# Altium schematic sheet [03] POWER.SchDoc("[03] POWER")
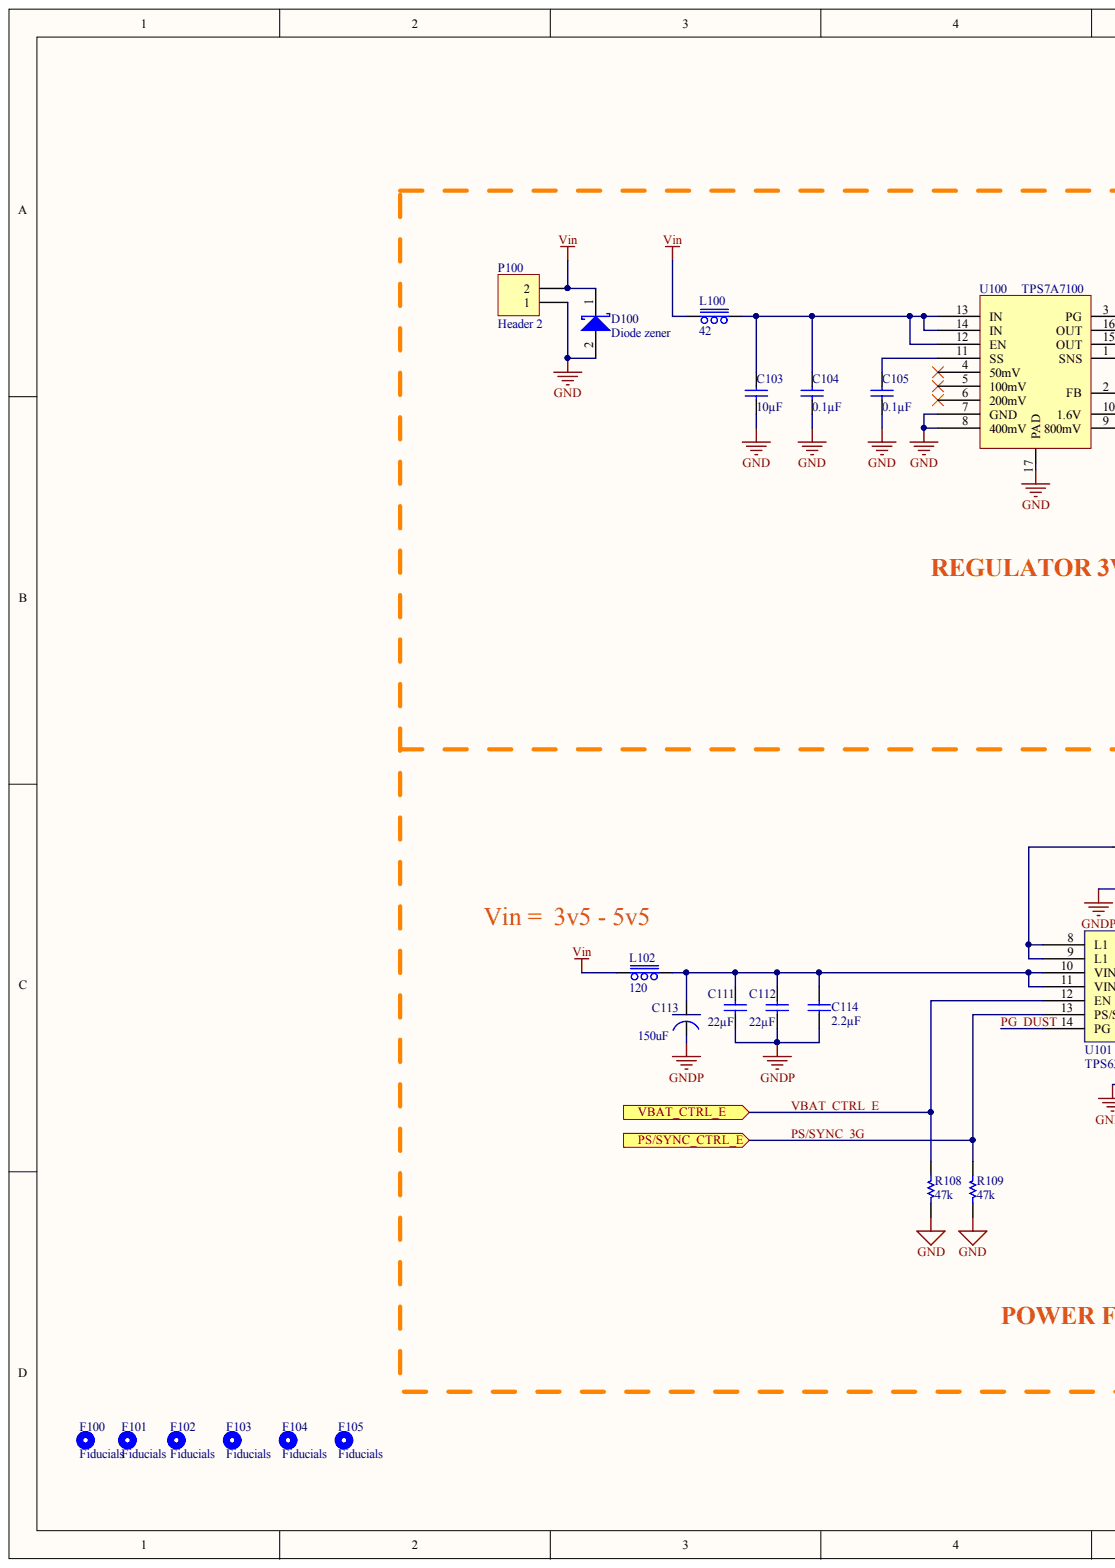
[diagram p0: [03] POWER.SchDoc, left]
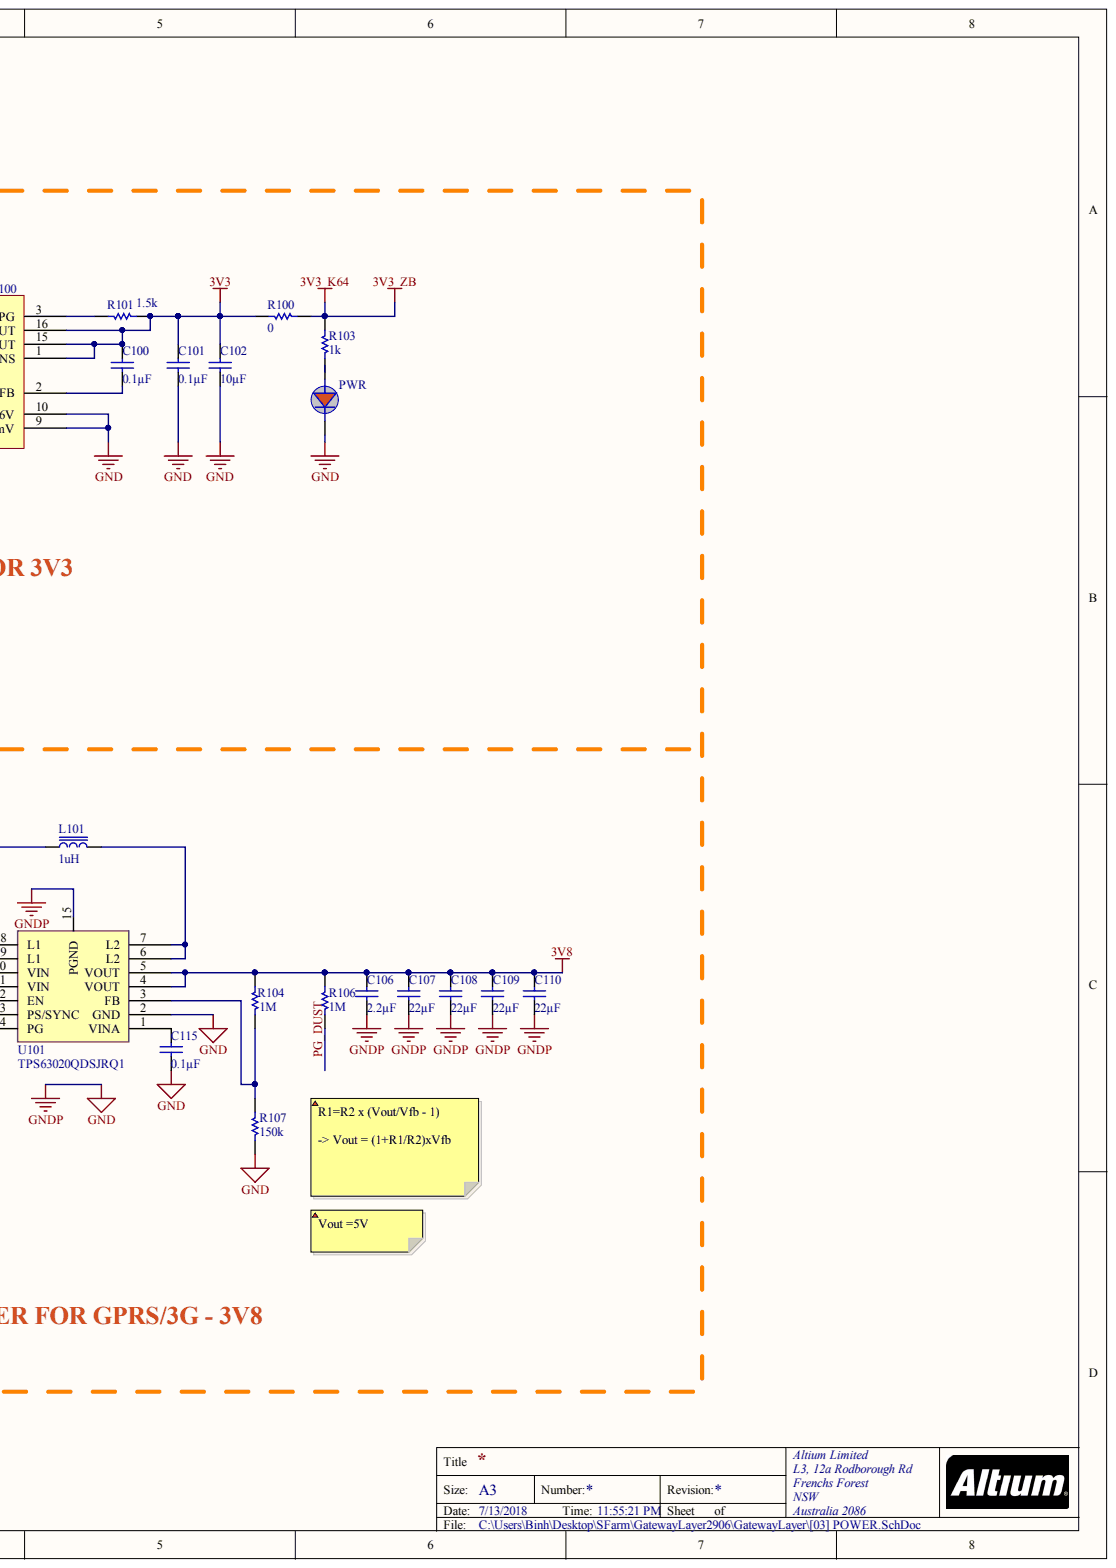
[diagram p1: [03] POWER.SchDoc, right]

## Parts
C100  0.1µF  [0402C]
C101  0.1µF  [0402C]
C102  10µF  [0805 cap]
C103  10µF  [0805 cap]
C104  0.1µF  [0402C]
C105  0.1µF  [0402C]
C106  2.2µF  [0402C]
C107  22µF  [0805 cap]
C108  22µF  [0805 cap]
C109  22µF  [0805 cap]
C110  22µF  [0805 cap]
C111  22µF  [0805 cap]
C112  22µF  [0805 cap]
C113  150uF  [CAPD7343]
C114  2.2µF  [0402C]
C115  0.1µF  [0402C]
D100  Diode zener  [SMA-diode]
F100  Fiducials  [fiducials]
F101  Fiducials  [fiducials]
F102  Fiducials  [fiducials]
F103  Fiducials  [fiducials]
F104  Fiducials  [fiducials]
F105  Fiducials  [fiducials]
L100  42  [0805F]
L101  1uH  [INDC4040X20N]
L102  120  [0805F]
P100  Header 2  [HED2]
PWR  (no value)  [0603LED-RED]
R100  0  [0805 Resistor]
R101  1.5k  [0603R]
R103  1k  [0603R]
R104  1M  [0402R]
R106  1M  [0603R]
R107  150k  [0402R]
R108  47k  [0603R]
R109  47k  [0603R]
U100  TPS7A7100  [QFN16]
U101  TPS63020QDSJRQ1  [VSON14]

## Ports
PS/SYNC_CTRL_E, VBAT_CTRL_E

## Nets
3V3: C100.2 C101.2 C102.2 R100.1 R101.2 U100.1(SNS) U100.15(OUT) U100.16(OUT)
3V3_K64: R100.2 R103.2
3V8: C106.2 C107.2 C108.2 C109.2 C110.2 R104.2 R106.2 U101.4(VOUT) U101.5(VOUT)
GND: C101.1 C102.1 C103.1 C104.1 C105.1 C106.1 C107.1 C108.1 C109.1 C110.1 C111.1 C112.1 C113.2 C114.1 C115.1 D100.2 P100.1 PWR.2 R107.1 R108.1 R109.1 U100.7(GND) U100.8(400mV) U100.9(800mV) U100.10(1.6V) U100.17(PAD) U101.2(GND) U101.15(PGND)
VIN: D100.1 L100.1 L102.1 P100.2
PG_DUST: R106.1 U101.14(PG)
PS/SYNC_3G: R109.2 U101.13(PS/SYNC) ; port PS/SYNC_CTRL_E
VBAT_CTRL_E: R108.2 U101.12(EN) ; port VBAT_CTRL_E
NetC100_1: C100.1 U100.2(FB)
NetC103_2: C103.2 C104.2 L100.2 U100.12(EN) U100.13(IN) U100.14(IN)
NetC105_2: C105.2 U100.11(SS)
NetC111_2: C111.2 C112.2 C113.1 C114.2 L102.2 U101.10(VIN) U101.11(VIN)
NetC115_2: C115.2 U101.1(VINA)
NetL101_1: L101.1 U101.8(L1) U101.9(L1)
NetL101_2: L101.2 U101.6(L2) U101.7(L2)
NetPWR_1: PWR.1 R103.1
NetR101_1: R101.1 U100.3(PG)
NetR104_1: R104.1 R107.2 U101.3(FB)

Not connected: U100 4(50mV) 5(100mV) 6(200mV)

## Library data of the parts
C100 C101 C104 C105 C115 = CAP0.1u0402: CAP CER 0.1UF 10V X7R 0402; Manufacturer: Taiyo Yuden; Manufacturer Part Number: LMK105B7104KVHF; Tolerance: ±10%; Applications: Automotive; Capacitance: 0.1µF; Category: Capacitors; Component Kind: Standard; ComponentLink1Description: http://www.yuden.co.jp/productdata/catalog/en/mlcc_all_hq_e.pdf; ComponentLink1URL: http://www.yuden.co.jp/productdata/catalog/en/mlcc_all_hq_e.pdf; Features: -; Height - Seated (Max): -; Lead Spacing: -; Lead Style: -; Mounting Type: Surface Mount, MLCC; Operating Temperature: -55°C ~ 125°C; Package / Case: 0402 (1005 Metric); Packaging: Cut Tape (CT); Part Status: Active; Pricing 1: 1=0.1, 10=0.05, 100=0.0223, 500=0.01594, 1000=0.01252, 2500=0.01138, 5000=0.01047 (USD); Ratings: AEC-Q200; RoHS: RoHS Compliant; Size / Dimension: 0.039" L x 0.020" W (1.00mm x 0.50mm); Stock 1: 23930; Supplier 1: Digi-Key; Supplier Part Number 1: 587-3769-1-ND; Temperature Coefficient: X7R; Thickness (Max): 0.022" (0.55mm); Voltage - Rated: 10V; Library Name: BinhLib.IntLib
C102 C103 = cap10u0805: CAP CER 10UF 16V X7R 0805; Manufacturer: Taiyo Yuden; Manufacturer Part Number: EMK212BB7106MG-T; Tolerance: ±20%; Applications: General Purpose; Capacitance: 10µF; Category: Capacitors; Component Kind: Standard; ComponentLink1Description: http://www.yuden.co.jp/productdata/catalog/en/mlcc06_e.pdf; ComponentLink1URL: http://www.yuden.co.jp/productdata/catalog/en/mlcc06_e.pdf; Features: -; Height - Seated (Max): -; Lead Spacing: -; Lead Style: -; Mounting Type: Surface Mount, MLCC; Operating Temperature: -55°C ~ 125°C; Package / Case: 0805 (2012 Metric); Packaging: Cut Tape (CT); Part Status: Active; Pricing 1: 1=0.51, 10=0.351, 100=0.2106, 500=0.15798, 1000=0.1334 (USD); Ratings: -; RoHS: RoHS Compliant; Size / Dimension: 0.079" L x 0.049" W (2.00mm x 1.25mm); Stock 1: 221600; Supplier 1: Digi-Key; Supplier Part Number 1: 587-3319-1-ND; Temperature Coefficient: X7R; Thickness (Max): 0.053" (1.35mm); Voltage - Rated: 16V; Library Name: BinhLib.IntLib
C106 C114 = cap2.2u0402: CAP CER 2.2UF 16V X5R 0402; Manufacturer: Taiyo Yuden; Manufacturer Part Number: EMK105ABJ225MV-F; Tolerance: ±20%; Applications: General Purpose; Capacitance: 2.2µF; Category: Capacitors; Component Kind: Standard; ComponentLink1Description: http://ds.yuden.co.jp/TYCOMPAS/ut/detail.do?productNo=EMK105ABJ225MV-F&fileName=EMK105ABJ225MV-F_SS&mode=specSheetDownload; ComponentLink1URL: http://ds.yuden.co.jp/TYCOMPAS/ut/detail.do?productNo=EMK105ABJ225MV-F&fileName=EMK105ABJ225MV-F_SS&mode=specSheetDownload; Features: -; Height - Seated (Max): -; Lead Spacing: -; Lead Style: -; Mounting Type: Surface Mount, MLCC; Operating Temperature: -55°C ~ 85°C; Package / Case: 0402 (1005 Metric); Packaging: Cut Tape (CT); Part Status: Active; Pricing 1: 1=0.27, 10=0.187, 100=0.1062, 500=0.07498, 1000=0.0656, 2500=0.06248, 5000=0.05779 (USD); Ratings: -; RoHS: RoHS Compliant; Size / Dimension: 0.039" L x 0.020" W (1.00mm x 0.50mm); Stock 1: 43595; Supplier 1: Digi-Key; Supplier Part Number 1: 587-3302-1-ND; Temperature Coefficient: X5R; Thickness (Max): 0.022" (0.55mm); Voltage - Rated: 16V; Library Name: BinhLib.IntLib
C107-C112 = cap22u0805: CAP CER 22UF 16V X5R 0805; Manufacturer: Taiyo Yuden; Manufacturer Part Number: EMK212BBJ226MG-T; Tolerance: ±20%; Applications: General Purpose; Capacitance: 22µF; Category: Capacitors; Component Kind: Standard; ComponentLink1Description: http://ds.yuden.co.jp/TYCOMPAS/ut/detail.do?productNo=EMK212BBJ226MG-T&fileName=EMK212BBJ226MG-T_SS&mode=specSheetDownload; ComponentLink1URL: http://ds.yuden.co.jp/TYCOMPAS/ut/detail.do?productNo=EMK212BBJ226MG-T&fileName=EMK212BBJ226MG-T_SS&mode=specSheetDownload; Features: -; Height - Seated (Max): -; Lead Spacing: -; Lead Style: -; Mounting Type: Surface Mount, MLCC; Operating Temperature: -55°C ~ 85°C; Package / Case: 0805 (2012 Metric); Packaging: Cut Tape (CT); Part Status: Active; Pricing 1: 1=0.55, 10=0.389, 100=0.2562, 500=0.18976, 1000=0.16129 (USD); Ratings: -; RoHS: RoHS Compliant; Size / Dimension: 0.079" L x 0.039" W (2.00mm x 1.00mm); Stock 1: 427128; Supplier 1: Digi-Key; Supplier Part Number 1: 587-3239-1-ND; Temperature Coefficient: X5R; Thickness (Max): 0.049" (1.25mm); Voltage - Rated: 16V; Library Name: BinhLib.IntLib
C113 = CAPTan150u7343: CAP TANT 150UF 10V 10% 2917; Manufacturer: AVX Corporation; Manufacturer Part Number: TPSD157K010R0085; Manufacturer Size Code: D; ESR (Equivalent Series Resistance): 85 mOhm; Type: Molded; Category: Capacitors; Component Kind: Standard; ComponentLink1Description: http://datasheets.avx.com/TPS.pdf; ComponentLink1URL: http://datasheets.avx.com/TPS.pdf; Failure Rate: -; Features: General Purpose; Height - Seated (Max): 0.122" (3.10mm); Lead Spacing: -; Lifetime @ Temp.: -; Mounting Type: Surface Mount; Operating Temperature: -55°C ~ 125°C; Package / Case: 2917 (7343 Metric); Packaging: Cut Tape (CT); Part Status: Active; Pricing 1: 1=1.8, 10=1.419, 100=1.0639 (USD); RoHS: RoHS Compliant; Size / Dimension: 0.287" L x 0.169" W (7.30mm x 4.30mm); Stock 1: 1344; Supplier 1: Digi-Key; Supplier Part Number 1: 478-9158-1-ND; Tolerance: ±10%; Library Name: BinhLib.IntLib
L100 = Ferrite Bead: FERRITE BEAD 42 OHM 0805 1LN; Manufacturer: Taiyo Yuden; Manufacturer Part Number: FBMJ2125HS420-TV; Current Rating (Max): 4A; DC Resistance (DCR) (Max): 8 mOhm; Filter Type: Power Line; Impedance @ Frequency: 42 Ohm @ 100MHz; Number of Lines: 1; Category: Filters; Component Kind: Standard; ComponentLink1Description: http://ds.yuden.co.jp/TYCOMPAS/ut/detail.do?productNo=FBMJ2125HS420-TV&fileName=FBMJ2125HS420-TV_SS&mode=specSheetDownload; ComponentLink1URL: http://ds.yuden.co.jp/TYCOMPAS/ut/detail.do?productNo=FBMJ2125HS420-TV&fileName=FBMJ2125HS420-TV_SS&mode=specSheetDownload; Height (Max): 0.041" (1.05mm); Mounting Type: Surface Mount; Operating Temperature: -40°C ~ 125°C; Package / Case: 0805 (2012 Metric); Packaging: Digi-Reel®; Part Status: Active; Pricing 1: 1=0.2, 10=0.154, 25=0.1276, 50=0.11, 100=0.099, 250=0.0836, 500=0.0748, 1000=0.0638 (USD); Ratings: AEC-Q200; RoHS: RoHS Compliant; Size / Dimension: 0.079" L x 0.049" W (2.00mm x 1.25mm); Stock 1: 2013; Supplier 1: Digi-Key; Supplier Part Number 1: 587-3851-6-ND; Library Name: BinhLib.IntLib
L101 = Inductor: Fixed Inductors XFL4020 Low DCR Ind 1 uH 20% 11 A Compos; Manufacturer: Coilcraft; Manufacturer Part Number: XFL4020-102MEB; Part # Aliases: XFL4020-102MEC; Case Height: 2.1 mm; Case Length: 4.3 mm; Core Material: Composite; Maximum DC Current: 11 A; Maximum DC Resistance: 11.9 mOhms; Self Resonant Frequency: 64 MHz; Shielding: Shielded; Test Frequency: 100 kHz; Case Width: 4.3 mm; Component Kind: Standard; ComponentLink1Description: https://datasheet.ciiva.com/13167/xfl4020-13167357.pdf; ComponentLink1URL: https://datasheet.ciiva.com/13167/xfl4020-13167357.pdf; Dimensions: 4.3 mm W x 4.3 mm L x 2.1 mm H; Inductance: 1 uH; Operating Temperature Range: - 40 C to + 125 C; Packaging: Cut Tape; Product: Power Inductors; RoHS: Details; Series: XFL4020; Supplier 1: Mouser; Supplier Part Number 1: 994-XFL4020-102MEB; Termination Style: SMD/SMT; Tolerance: 20 %; Library Name: BinhLib.IntLib
L102 = Ferrite Bead: Manufacturer: MURATA; Manufacturer Part Number: BLM18PG121SN1D; DC Current Rating: 2; DC Resistance Max: 0.05; Ferrite Case Style: 0603 [1608 Metric]; Impedance Tolerance: 25%; Product Range: BLM18; Automotive Qualifications Standard: -; Component Kind: Standard; ComponentLink1Description: http://www.farnell.com/datasheets/1740433.pdf; ComponentLink1URL: http://www.farnell.com/datasheets/1740433.pdf; ComponentLink2Description: http://www.farnell.com/datasheets/5148.pdf; ComponentLink2URL: http://www.farnell.com/datasheets/5148.pdf; Packaging: Each; Pricing 1: 1=0.039, 50=0.036, 100=0.029, 500=0.026, 1000=0.023, 2500=0.022, 5000=0.02, 10000=0.019 (USD); RoHS: YES; Stock 1: 28827; Supplier 1: Newark; Supplier Part Number 1: 73M9109; Library Name: BinhLib.IntLib
P100 = Header 2: Header, 2-Pin; Component Kind: Standard; LatestRevisionDate: 17-Jul-2002; LatestRevisionNote: Re-released for DXP Platform.; Signal Integrity: Connector; Library Name: BinhLib.IntLib; Publisher: Altium Limited
PWR = LedRed0603: LED RED CLEAR 0603 SMD; Manufacturer: Everlight Electronics Co Ltd; Manufacturer Part Number: QTLP600C7TR; Current - Test: 20mA; Lens Color: Colorless; Lens Style/Size: Rectangle with Flat Top, 1.20mm x 0.80mm; Millicandela Rating: 20mcd; Viewing Angle: 100°; Voltage - Forward (Vf) (Typ): 1.9V; Wavelength - Dominant: 645nm; Wavelength - Peak: 660nm; Category: Optoelectronics; Color: Red; Component Kind: Standard; ComponentLink1Description: http://media.digikey.com/pdf/Data%20Sheets/Everlight%20PDFs/QTLP600C.pdf; ComponentLink1URL: http://media.digikey.com/pdf/Data%20Sheets/Everlight%20PDFs/QTLP600C.pdf; Features: -; Height (Max): 0.80mm; Lens Transparency: Clear; Mounting Type: Surface Mount; Package / Case: 0603 (1608 Metric); Packaging: Cut Tape (CT); Part Status: Active; Pricing 1: 1=0.41, 10=0.297, 25=0.2276, 100=0.1485, 250=0.10892, 500=0.0924, 1000=0.0759 (USD); RoHS: RoHS Compliant; Size / Dimension: 1.60mm L x 0.80mm W; Stock 1: 19325; Supplier 1: Digi-Key; Supplier Part Number 1: 1080-1400-1-ND; Library Name: BinhLib.IntLib
R100 = RES00805: RES SMD 0.0 OHM JUMPER 1/8W 0805; Manufacturer: Panasonic Electronic Components; Manufacturer Part Number: ERJ-6GEY0R00V; Power (Watts): 0.125W, 1/8W; Resistance (Ohms): 0.0; Category: Resistors; Component Kind: Standard; ComponentLink1Description: http://industrial.panasonic.com/www-cgi/jvcr13pz.cgi?E+PZ+3+AOA0001+ERJ6GEY0R00V+7+WW; ComponentLink1URL: http://industrial.panasonic.com/www-cgi/jvcr13pz.cgi?E+PZ+3+AOA0001+ERJ6GEY0R00V+7+WW; Composition: Thick Film; Failure Rate: -; Features: Automotive AEC-Q200; Height - Seated (Max): 0.028" (0.70mm); Operating Temperature: -55°C ~ 155°C; Package / Case: 0805 (2012 Metric); Packaging: Cut Tape (CT); Part Status: Active; Pricing 1: 1=0.1, 10=0.024, 25=0.0172, 50=0.0132, 100=0.0097, 250=0.00744, 500=0.00594, 1000=0.00437 (USD); RoHS: RoHS Compliant; Size / Dimension: 0.079" L x 0.049" W (2.00mm x 1.25mm); Stock 1: 562130; Supplier 1: Digi-Key; Supplier Device Package: 0805; Supplier Part Number 1: P0.0ACT-ND; Temperature Coefficient: -; Tolerance: Jumper; Library Name: BinhLib.IntLib
R101 = RES1k50603: RES SMD 1.5K OHM 1% 1/10W 0603; Manufacturer: Panasonic Electronic Components; Manufacturer Part Number: ERJ-3EKF1501V; Power (Watts): 0.1W, 1/10W; Temperature Coefficient: ±100ppm/°C; Category: Resistors; Component Kind: Standard; ComponentLink1Description: https://industrial.panasonic.com/cdbs/www-data/pdf/RDA0000/AOA0000C298.pdf; ComponentLink1URL: https://industrial.panasonic.com/cdbs/www-data/pdf/RDA0000/AOA0000C298.pdf; Composition: Thick Film; Failure Rate: -; Features: Automotive AEC-Q200; Height - Seated (Max): 0.022" (0.55mm); Operating Temperature: -55°C ~ 155°C; Package / Case: 0603 (1608 Metric); Packaging: Cut Tape (CT); Part Status: Active; Pricing 1: 1=0.1, 10=0.021, 100=0.0087, 1000=0.00389, 2500=0.00338 (USD); Resistance (Ohms): 1.5k; RoHS: RoHS Compliant; Size / Dimension: 0.063" L x 0.031" W (1.60mm x 0.80mm); Stock 1: 0; Supplier 1: Digi-Key; Supplier Device Package: 0603; Supplier Part Number 1: P1.50KHCT-ND; Tolerance: ±1%; Library Name: BinhLib.IntLib
R103 = RES1k0603: RES SMD 1K OHM 1% 1/10W 0603; Manufacturer: Panasonic Electronic Components; Manufacturer Part Number: ERJ-3EKF1001V; Power (Watts): 0.1W, 1/10W; Temperature Coefficient: ±100ppm/°C; Category: Resistors; Component Kind: Standard; ComponentLink1Description: https://industrial.panasonic.com/cdbs/www-data/pdf/RDA0000/AOA0000C298.pdf; ComponentLink1URL: https://industrial.panasonic.com/cdbs/www-data/pdf/RDA0000/AOA0000C298.pdf; Composition: Thick Film; Failure Rate: -; Features: Automotive AEC-Q200; Height - Seated (Max): 0.022" (0.55mm); Operating Temperature: -55°C ~ 155°C; Package / Case: 0603 (1608 Metric); Packaging: Digi-Reel®; Part Status: Active; Pricing 1: 1=0.1, 10=0.021, 100=0.0087, 1000=0.00389, 2500=0.00338 (USD); Resistance (Ohms): 1k; RoHS: RoHS Compliant; Size / Dimension: 0.063" L x 0.031" W (1.60mm x 0.80mm); Stock 1: 0; Supplier 1: Digi-Key; Supplier Device Package: 0603; Supplier Part Number 1: P1.00KHDKR-ND; Tolerance: ±1%; Library Name: BinhLib.IntLib
R104 = RES1M0402: RES SMD 1M OHM 1% 1/10W 0402; Manufacturer: Panasonic Electronic Components; Manufacturer Part Number: ERJ-2RKF1004X; Power (Watts): 0.1W, 1/10W; Temperature Coefficient: ±100ppm/°C; Category: Resistors; Component Kind: Standard; ComponentLink1Description: https://industrial.panasonic.com/cdbs/www-data/pdf/RDA0000/AOA0000C298.pdf; ComponentLink1URL: https://industrial.panasonic.com/cdbs/www-data/pdf/RDA0000/AOA0000C298.pdf; Composition: Thick Film; Failure Rate: -; Features: Automotive AEC-Q200; Height - Seated (Max): 0.016" (0.40mm); Operating Temperature: -55°C ~ 155°C; Package / Case: 0402 (1005 Metric); Packaging: Cut Tape (CT); Part Status: Active; Pricing 1: 1=0.1, 10=0.028, 100=0.0113, 1000=0.00509, 2500=0.00442, 5000=0.00365 (USD); Resistance (Ohms): 1M; RoHS: RoHS Compliant; Size / Dimension: 0.039" L x 0.020" W (1.00mm x 0.50mm); Stock 1: 0; Supplier 1: Digi-Key; Supplier Device Package: 0402; Supplier Part Number 1: P1.00MLCT-ND; Tolerance: ±1%; Library Name: BinhLib.IntLib
R106 = RES1M0603: RES SMD 1M OHM 1% 1/10W 0603; Manufacturer: Panasonic Electronic Components; Manufacturer Part Number: ERJ-3EKF1004V; Power (Watts): 0.1W, 1/10W; Temperature Coefficient: ±100ppm/°C; Category: Resistors; Component Kind: Standard; ComponentLink1Description: https://industrial.panasonic.com/cdbs/www-data/pdf/RDA0000/AOA0000C298.pdf; ComponentLink1URL: https://industrial.panasonic.com/cdbs/www-data/pdf/RDA0000/AOA0000C298.pdf; Composition: Thick Film; Failure Rate: -; Features: Automotive AEC-Q200; Height - Seated (Max): 0.022" (0.55mm); Operating Temperature: -55°C ~ 155°C; Package / Case: 0603 (1608 Metric); Packaging: Cut Tape (CT); Part Status: Active; Pricing 1: 1=0.1, 10=0.021, 100=0.0087, 1000=0.00389, 2500=0.00338 (USD); Resistance (Ohms): 1M; RoHS: RoHS Compliant; Size / Dimension: 0.063" L x 0.031" W (1.60mm x 0.80mm); Stock 1: 0; Supplier 1: Digi-Key; Supplier Device Package: 0603; Supplier Part Number 1: P1.00MHCT-ND; Tolerance: ±1%; Library Name: BinhLib.IntLib
R107 = Resistor: RES SMD 150K OHM 1% 1/10W 0402; Manufacturer: Panasonic Electronic Components; Manufacturer Part Number: ERJ-2RKF1503X; Power (Watts): 0.1W, 1/10W; Temperature Coefficient: ±100ppm/°C; Category: Resistors; Component Kind: Standard; ComponentLink1Description: https://industrial.panasonic.com/cdbs/www-data/pdf/RDA0000/AOA0000C298.pdf; ComponentLink1URL: https://industrial.panasonic.com/cdbs/www-data/pdf/RDA0000/AOA0000C298.pdf; Composition: Thick Film; Failure Rate: -; Features: Automotive AEC-Q200; Height - Seated (Max): 0.016" (0.40mm); Operating Temperature: -55°C ~ 155°C; Package / Case: 0402 (1005 Metric); Packaging: Cut Tape (CT); Part Status: Active; Pricing 1: 1=0.1, 10=0.028, 100=0.0113, 1000=0.00509, 2500=0.00442, 5000=0.00365 (USD); Resistance (Ohms): 150k; RoHS: RoHS Compliant; Size / Dimension: 0.039" L x 0.020" W (1.00mm x 0.50mm); Stock 1: 0; Supplier 1: Digi-Key; Supplier Device Package: 0402; Supplier Part Number 1: P150KLCT-ND; Tolerance: ±1%; Library Name: BinhLib.IntLib
R108 R109 = RES47k0603: RES SMD 47K OHM 1% 1/10W 0603; Manufacturer: Panasonic Electronic Components; Manufacturer Part Number: ERJ-3EKF4702V; Power (Watts): 0.1W, 1/10W; Temperature Coefficient: ±100ppm/°C; Category: Resistors; Component Kind: Standard; ComponentLink1Description: https://industrial.panasonic.com/cdbs/www-data/pdf/RDA0000/AOA0000C298.pdf; ComponentLink1URL: https://industrial.panasonic.com/cdbs/www-data/pdf/RDA0000/AOA0000C298.pdf; Composition: Thick Film; Failure Rate: -; Features: Automotive AEC-Q200; Height - Seated (Max): 0.022" (0.55mm); Operating Temperature: -55°C ~ 155°C; Package / Case: 0603 (1608 Metric); Packaging: Cut Tape (CT); Part Status: Active; Pricing 1: 1=0.1, 10=0.021, 100=0.0087, 1000=0.00389, 2500=0.00338 (USD); Resistance (Ohms): 47k; RoHS: RoHS Compliant; Size / Dimension: 0.063" L x 0.031" W (1.60mm x 0.80mm); Stock 1: 2205285; Supplier 1: Digi-Key; Supplier Device Package: 0603; Supplier Part Number 1: P47.0KHCT-ND; Tolerance: ±1%; Library Name: BinhLib.IntLib
U100 = TPS7A7100: IC REG LDO FIX/ADJ 1A 16QFN; Manufacturer: Texas Instruments; Manufacturer Part Number: TPS7A7100RGTR; Current - Limit (Min): 1.1A; Regulator Topology: Positive Fixed or Adjustable; Voltage - Input: 1.425 V ~ 6.5 V; Voltage - Output: 0.9 V ~ 5 V; Category: Integrated Circuits (ICs); Component Kind: Standard; ComponentLink1Description: http://www.ti.com/lit/ds/symlink/tps7a7100.pdf; ComponentLink1URL: http://www.ti.com/lit/ds/symlink/tps7a7100.pdf; Current - Output: 1A; Mounting Type: Surface Mount; Operating Temperature: -40°C ~ 125°C; Package / Case: 16-VFQFN Exposed Pad; Packaging: Cut Tape (CT); Part Status: Active; Pricing 1: 1=2.19, 10=1.965, 100=1.5796, 500=1.2978, 1000=1.07532 (USD); RoHS: RoHS Compliant; Stock 1: 5133; Supplier 1: Digi-Key; Supplier Device Package: 16-QFN (3x3); Supplier Part Number 1: 296-40978-1-ND; Voltage - Dropout (Typical): -; Library Name: BinhLib.IntLib
U101 = TPS63020: IC REG BUCK BST ADJ 3.5A; Manufacturer: Texas Instruments; Current - Output: 3.5A (Switch); Frequency - Switching: 2.4MHz; Function: Step-Up/Step-Down; Number of Outputs: 1; Output Configuration: Positive; Output Type: Adjustable; Synchronous Rectifier: Yes; Topology: Buck-Boost; Voltage - Input (Max): 5.5V; Voltage - Input (Min): 1.8V; Voltage - Output (Min/Fixed): 1.2V; Category: Integrated Circuits (ICs); Component Kind: Standard; ComponentLink1Description: http://www.ti.com/lit/ds/symlink/tps63020-q1.pdf; ComponentLink1URL: http://www.ti.com/lit/ds/symlink/tps63020-q1.pdf; Manufacturer Part Number: TPS63020QDSJRQ1; Mounting Type: Surface Mount; Operating Temperature: -40°C ~ 125°C (TJ); Package / Case: 14-VFDFN Exposed Pad; Packaging: Cut Tape (CT); Part Status: Active; Pricing 1: 1=3.33, 10=2.993, 100=2.4524, 500=2.08766, 1000=1.76068 (USD); RoHS: RoHS Compliant; Stock 1: 3331; Supplier 1: Digi-Key; Supplier Device Package: 14-VSON (4x3); Supplier Part Number 1: 296-43286-1-ND; Voltage - Output (Max): 5.5V; Library Name: BinhLib.IntLib
D100 = Diode zener: Component Kind: Standard; Library Name: BinhLib.IntLib
F100-F105 = Fiducials: Component Kind: Standard; Library Name: BinhLib.IntLib
